# Altium schematic sheet CorrosenseBoard_V4.2.SchDoc
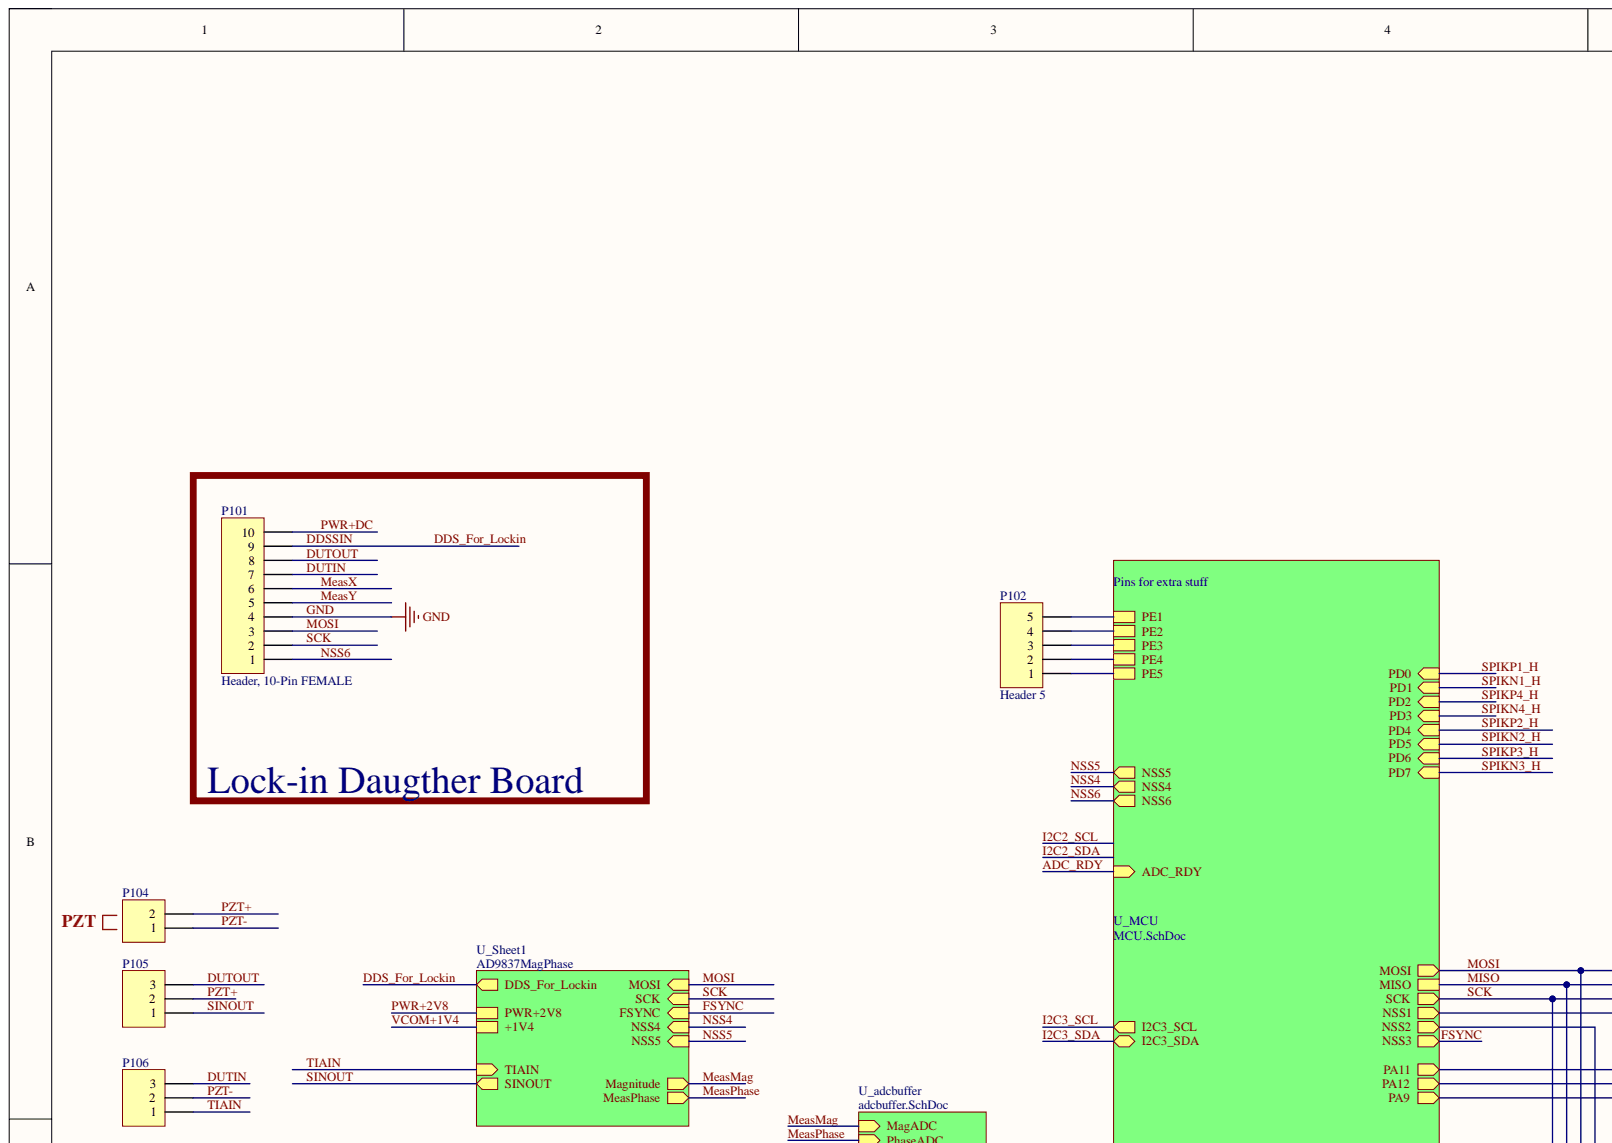
[diagram p0: CorrosenseBoard_V4.2.SchDoc, top left]
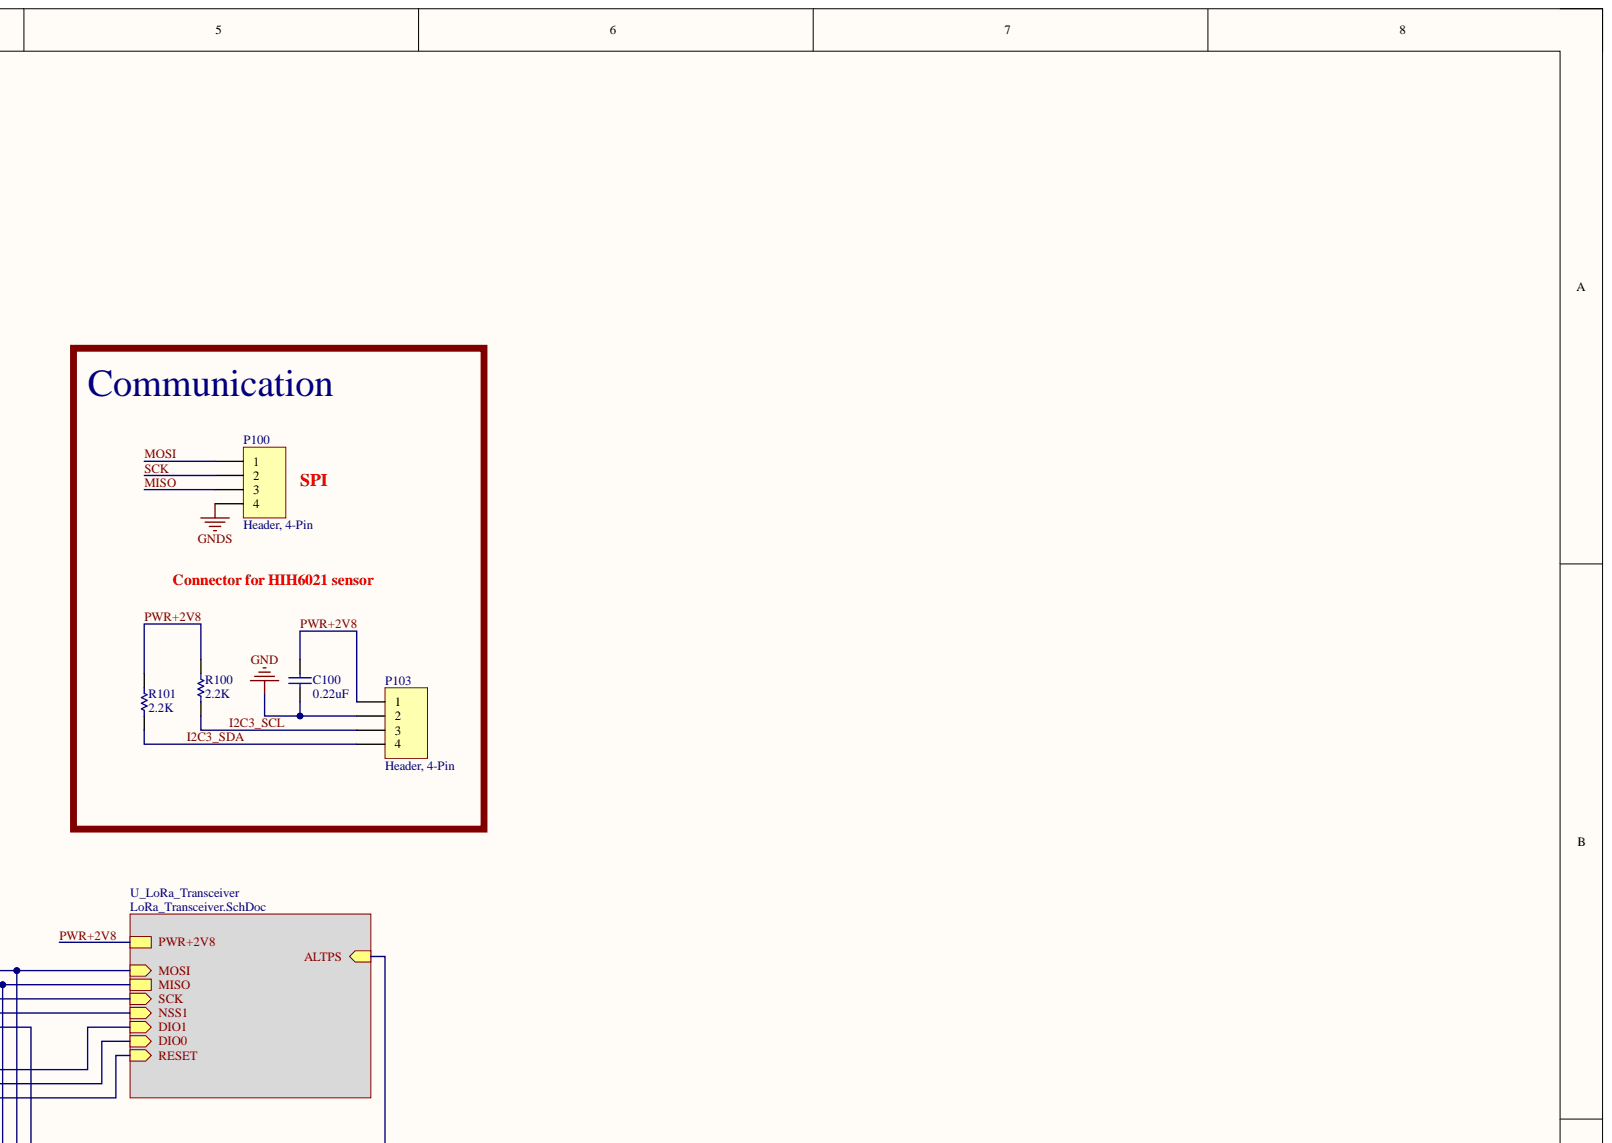
[diagram p1: CorrosenseBoard_V4.2.SchDoc, top right]
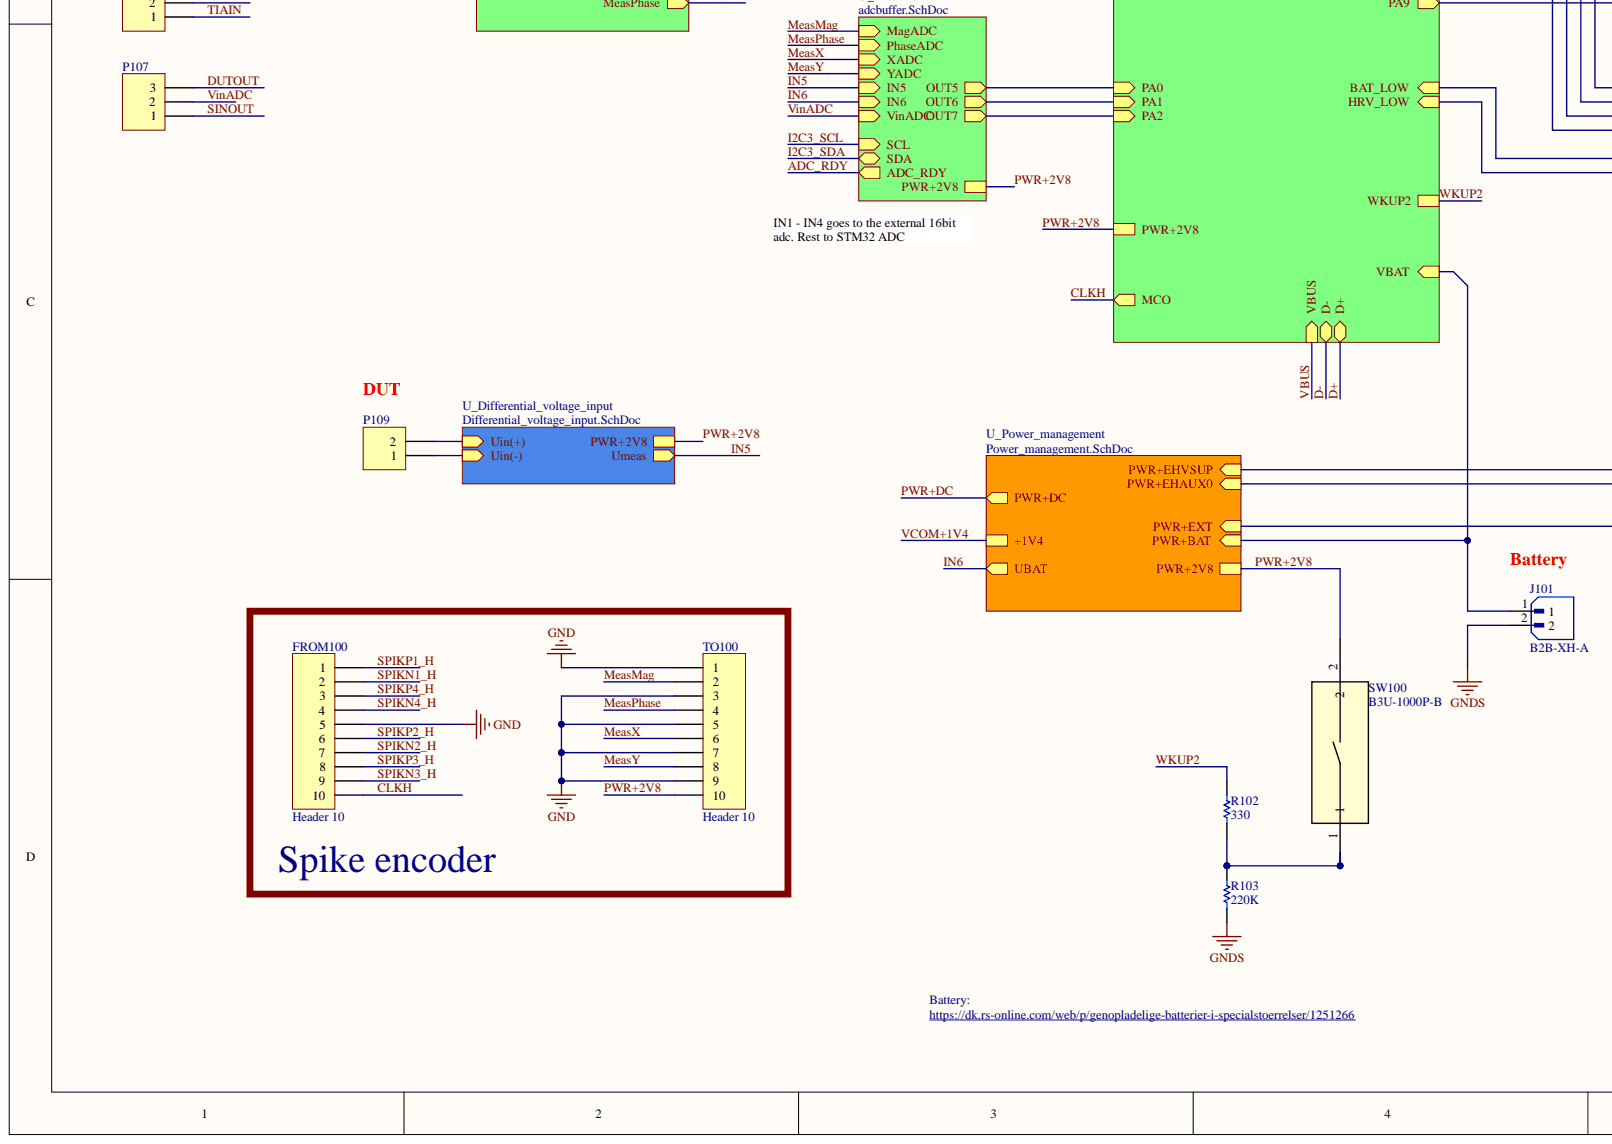
[diagram p2: CorrosenseBoard_V4.2.SchDoc, bottom left]
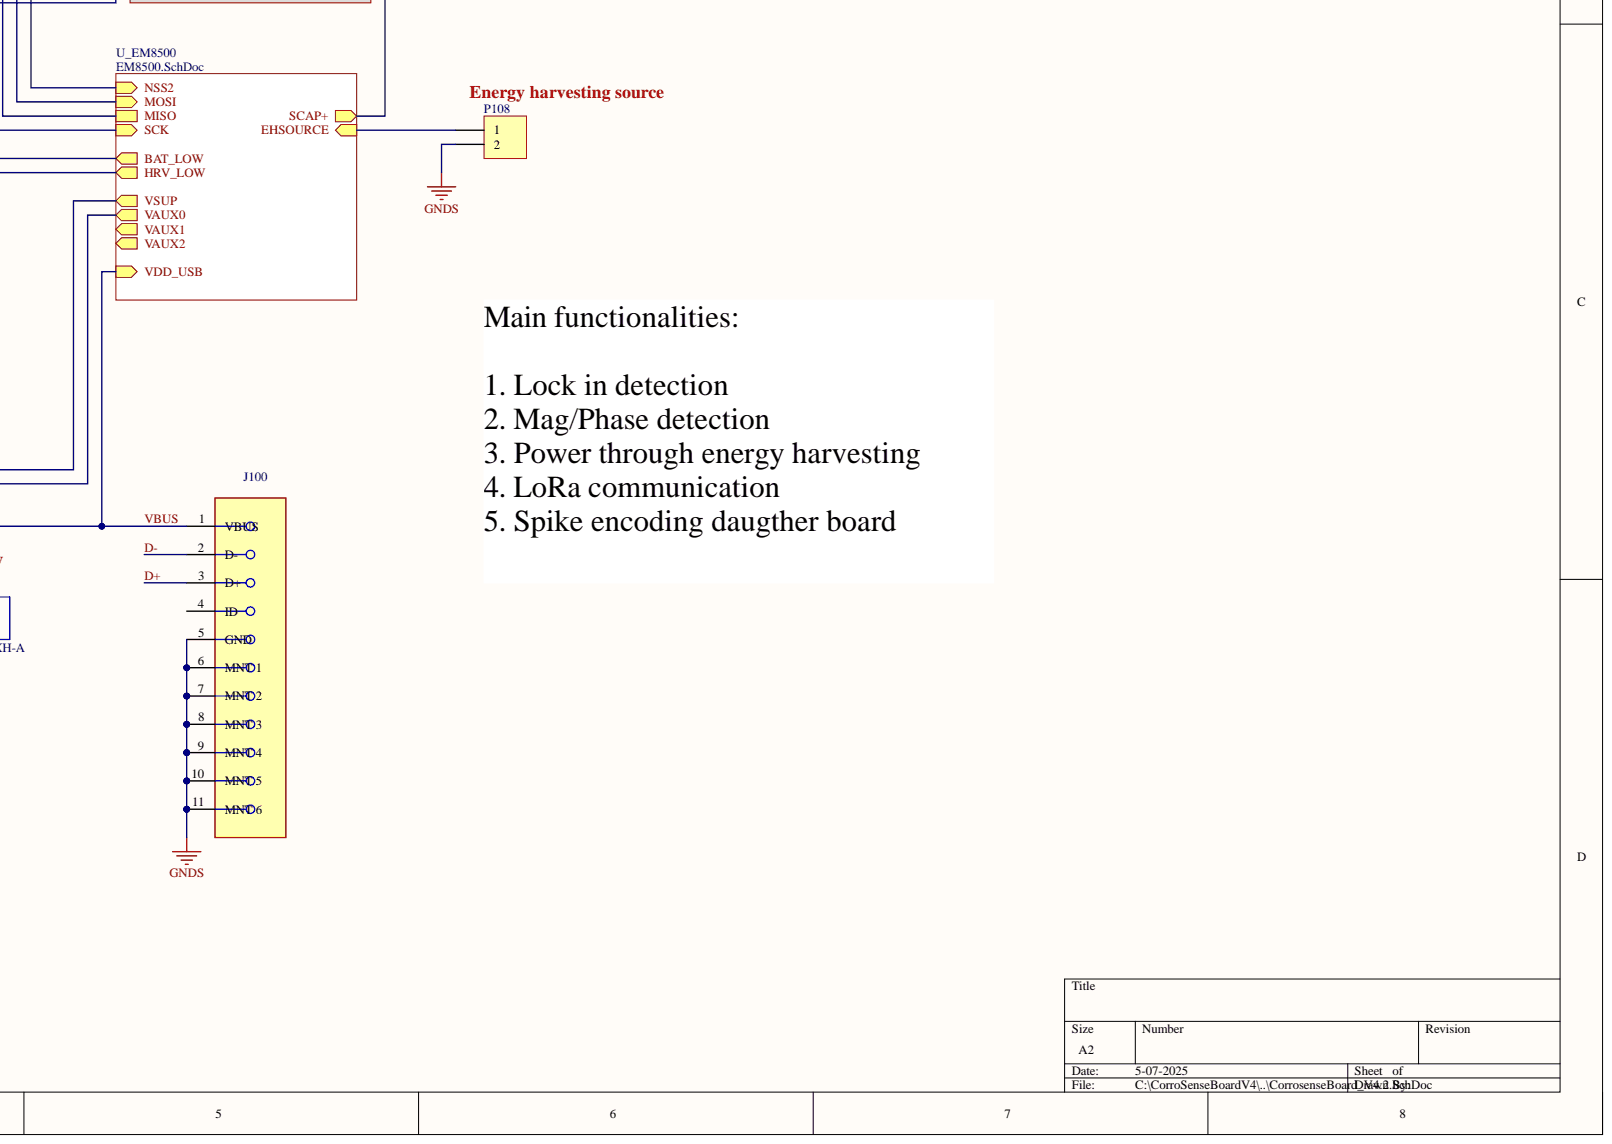
[diagram p3: CorrosenseBoard_V4.2.SchDoc, bottom right]

## Parts
C100  0.22uF  [1608[0603]]
FROM100  Header 10  [HDR1X10]
J100  0473460001  [Molex_0473460001_0]  (value not drawn)
J101  B2B-XH-A  [JST_B2B-XH-A]
P100  Header, 4-Pin  [HDR1X4]
P101  Header, 10-Pin FEMALE  [HDR1X10]
P102  Header 5  [HDR1X5]
P103  Header, 4-Pin  [HDR1X4]
P104  Header, 2-Pin  [HDR1X2]  (value not drawn)
P105  Header, 3-Pin  [HDR1X3]  (value not drawn)
P106  Header, 3-Pin  [HDR1X3]  (value not drawn)
P107  Header, 3-Pin  [HDR1X3]  (value not drawn)
P108  Header, 2-Pin  [HDR1X2]  (value not drawn)
P109  Header, 2-Pin  [HDR1X2]  (value not drawn)
R100  2.2K  [1608[0603]]
R101  2.2K  [1608[0603]]
R102  330  [1608[0603]]
R103  220K  [1608[0603]]
SW100  B3U-1000P-B  [B3U-1000P-B]
TO100  Header 10  [HDR1X10]

## Nets
GNDS: J100.5(GND) J100.6(MNT_1) J100.7(MNT_2) J100.8(MNT_3) J100.9(MNT_4) J100.10(MNT_5) J100.11(MNT_6) J101.2 P100.4 P108.2 R103.1
CLKH: FROM100.10
D+: J100.3(D+)
D-: J100.2(D-)
DDS_For_Lockin: P101.9
DUTIN: P101.7 P106.3
DUTOUT: P101.8 P105.3 P107.3
GND: C100.1 FROM100.5 P101.4 P103.2 TO100.1 TO100.3 TO100.5 TO100.7 TO100.9
I2C3_SCL: P103.3 R100.1
I2C3_SDA: P103.4 R101.1
MeasMag: TO100.2
MeasPhase: TO100.4
MeasX: P101.6 TO100.6
MeasY: P101.5 TO100.8
MISO: P100.3
MOSI: P100.1 P101.3
NSS6: P101.1
PWR+2V8: C100.2 P103.1 R100.2 R101.2 SW100.2 TO100.10
PWR+DC: P101.10
PZT+: P104.2 P105.2
PZT-: P104.1 P106.2
SCK: P100.2 P101.2
SINOUT: P105.1 P107.1
SPIKN1_H: FROM100.2
SPIKN2_H: FROM100.7
SPIKN3_H: FROM100.9
SPIKN4_H: FROM100.4
SPIKP1_H: FROM100.1
SPIKP2_H: FROM100.6
SPIKP3_H: FROM100.8
SPIKP4_H: FROM100.3
TIAIN: P106.1
VBUS: J100.1(VBUS)
VinADC: P107.2
WKUP2: R102.2
NetJ100_4: J100.4(ID)
NetJ101_1: J101.1
NetP102_1: P102.1
NetP102_2: P102.2
NetP102_3: P102.3
NetP102_4: P102.4
NetP102_5: P102.5
NetP108_1: P108.1
NetP109_1: P109.1
NetP109_2: P109.2
NetR102_1: R102.1 R103.2 SW100.1
ADC_RDY:
ALTPS:
BAT_LOW:
DIO0:
DIO1:
FSYNC:
HRV_LOW:
I2C2_SCL:
I2C2_SDA:
IN5:
IN6:
NSS1:
NSS2:
NSS4:
NSS5:
OUT5:
OUT6:
OUT7:
PA9:
PWR+EHAUX0:
PWR+EHVSUP:
VAUX1:
VAUX2:
VCOM+1V4:

## Library data of the parts
C100 = Cap: CAP CER 25V X7R 0603; Manufacturer: Murata Electronics; MPN: GCM188R71E224KA55D; Tolerance: ±10%; Component Kind: Standard; Library Name: Miscellaneous Devices.IntLib; Operating Temperature: -55°C ~ 125°C; Package / Case: 0603 (1608 Metric); Signal Integrity: Cap; Simulation: CAP; Temperature Coefficient: X7R; Value: 0.22uF; Voltage - Rated: 25V
FROM100 TO100 = Header 10: Header, 10-Pin; Manufacturer: Wurth Elektronik; MPN: 61301011121; Component Kind: Standard; Library Name: Miscellaneous Connectors.IntLib; Signal Integrity: Connector; Publisher: Altium Limited
J100 = 0473460001: USB Connectors MICRO USB B RECPT BTTM MOUNT ASSY; Manufacturer: Molex; Component Kind: Standard; Library Name: Conn_Molex_0473460001_eec.SchLib; MPN: 0473460001
J101 = B2B-XH-A: B2B-XH-A(LF)(SN); Manufacturer: JST Commercial; MF: {'manufacturer': u'JST Sales America Inc.'}; Availability: Unavailable; Component Kind: Standard; Library Name: B2B-XH-A.IntLib; MP: B2B-XH-A; MPN: B2B-XH-A(LF)(SN); Package: None; Price: None; Value: B2B-XH-A(LF)(SN)
P100 P103 = Header 4: Manufacturer: Würth Elektronik; MPN: 61300411121; Component Kind: Standard; Description: Header, 4-Pin; Library Name: Miscellaneous Connectors.IntLib; Signal Integrity: Connector; Publisher: Altium Limited
P101 = Header 10: Header, 10-Pin; Manufacturer: Wurth Elektronik; MPN: 61301011821; Component Kind: Standard; Library Name: Miscellaneous Connectors.IntLib; Signal Integrity: Connector; Publisher: Altium Limited
P102 = Header 5: Header, 5-Pin; Manufacturer: Würth Elektronik; MPN: 61300511121; Component Kind: Standard; Library Name: Miscellaneous Connectors.IntLib; Signal Integrity: Connector; Publisher: Altium Limited
P104 P108 P109 = Header 2: Manufacturer: Wurth Elektronik; MPN: 61300211121; Component Kind: Standard; Description: Header, 2-Pin; Library Name: Miscellaneous Connectors.IntLib; Signal Integrity: Connector; Publisher: Altium Limited
P105-P107 = Header 3: Manufacturer: Wurth Elektronik; MPN: 61300311121; Component Kind: Standard; Description: Header, 3-Pin; Library Name: Miscellaneous Connectors.IntLib; Signal Integrity: Connector; Publisher: Altium Limited
R100 = Res1: RES SMD 2% 1.5W 0603; Manufacturer: YAGEO; MPN: RC0603FR-072K2L; Component Kind: Standard; Composition: Thick Film; Library Name: Miscellaneous Devices.IntLib; Operating Temperature: -65°C ~ 155°C; Package: 0603 (1608 Metric); Pin Info: Res; Power (Watts): 1.5W; Signal Integrity: RESISTOR; Simulation: RESISTOR; Tolerance: 2%; Value: 2.2K; Publisher: Altium Limited
R101 = Res1: 1608[0603]; Manufacturer: YAGEO; MPN: RC0603FR-072K2L; MP: -65°C ~ 155°C; Power (Watts): 1.5W; Temperature Coefficient: 2%; Code_JEITA: Thick Film; Component Kind: Standard; Composition: Thick Film; Ibis Model: Miscellaneous Devices.IntLib; Library Name: Res1; Operating Temperature: -65°C ~ 155°C; Package: 0603 (1608 Metric); PackageReference: 1.5W; Pin Info: Res; Published: Altium Limited; Signal Integrity: RESISTOR; Simulation: RESISTOR; Tolerance: 2%; Value: 2.2K; Publisher: Altium Limited
R102 = Res1: 1608[0603]; Manufacturer: YAGEO; MPN: AC0603FR-07330RL; MP: -65°C ~ 155°C; Power (Watts): 1.5W; Temperature Coefficient: 2%; Code_JEITA: Thick Film; Component Kind: Standard; Composition: Thick Film; Ibis Model: Miscellaneous Devices.IntLib; Library Name: Res1; Operating Temperature: -65°C ~ 155°C; Package: 0603 (1608 Metric); PackageReference: 1.5W; Pin Info: Res; Published: Altium Limited; Signal Integrity: RESISTOR; Simulation: RESISTOR; Tolerance: 2%; Value: 330; Publisher: Altium Limited
R103 = Res1: 1608[0603]; Manufacturer: YAGEO; MPN: RC0603FR-07220KL; MP: -65°C ~ 155°C; Power (Watts): 1.5W; Temperature Coefficient: 2%; Code_JEITA: Thick Film; Component Kind: Standard; Composition: Thick Film; Ibis Model: Miscellaneous Devices.IntLib; Library Name: Res1; Operating Temperature: -65°C ~ 155°C; Package: 0603 (1608 Metric); PackageReference: 1.5W; Pin Info: Res; Published: Altium Limited; Signal Integrity: RESISTOR; Simulation: RESISTOR; Tolerance: 2%; Value: 220K; Publisher: Altium Limited
SW100 = B3U-1000P-B: No Description Available; Manufacturer: Omron Electronics; Mfr_Name: Omron; Component Kind: Standard; Library Name: B3U-1000P-B.SchLib; MPN: B3U-1000P-B; RefDes: RefDes; Type: Type
